# Altium schematic sheet Schematic.SchDoc(Schematic)
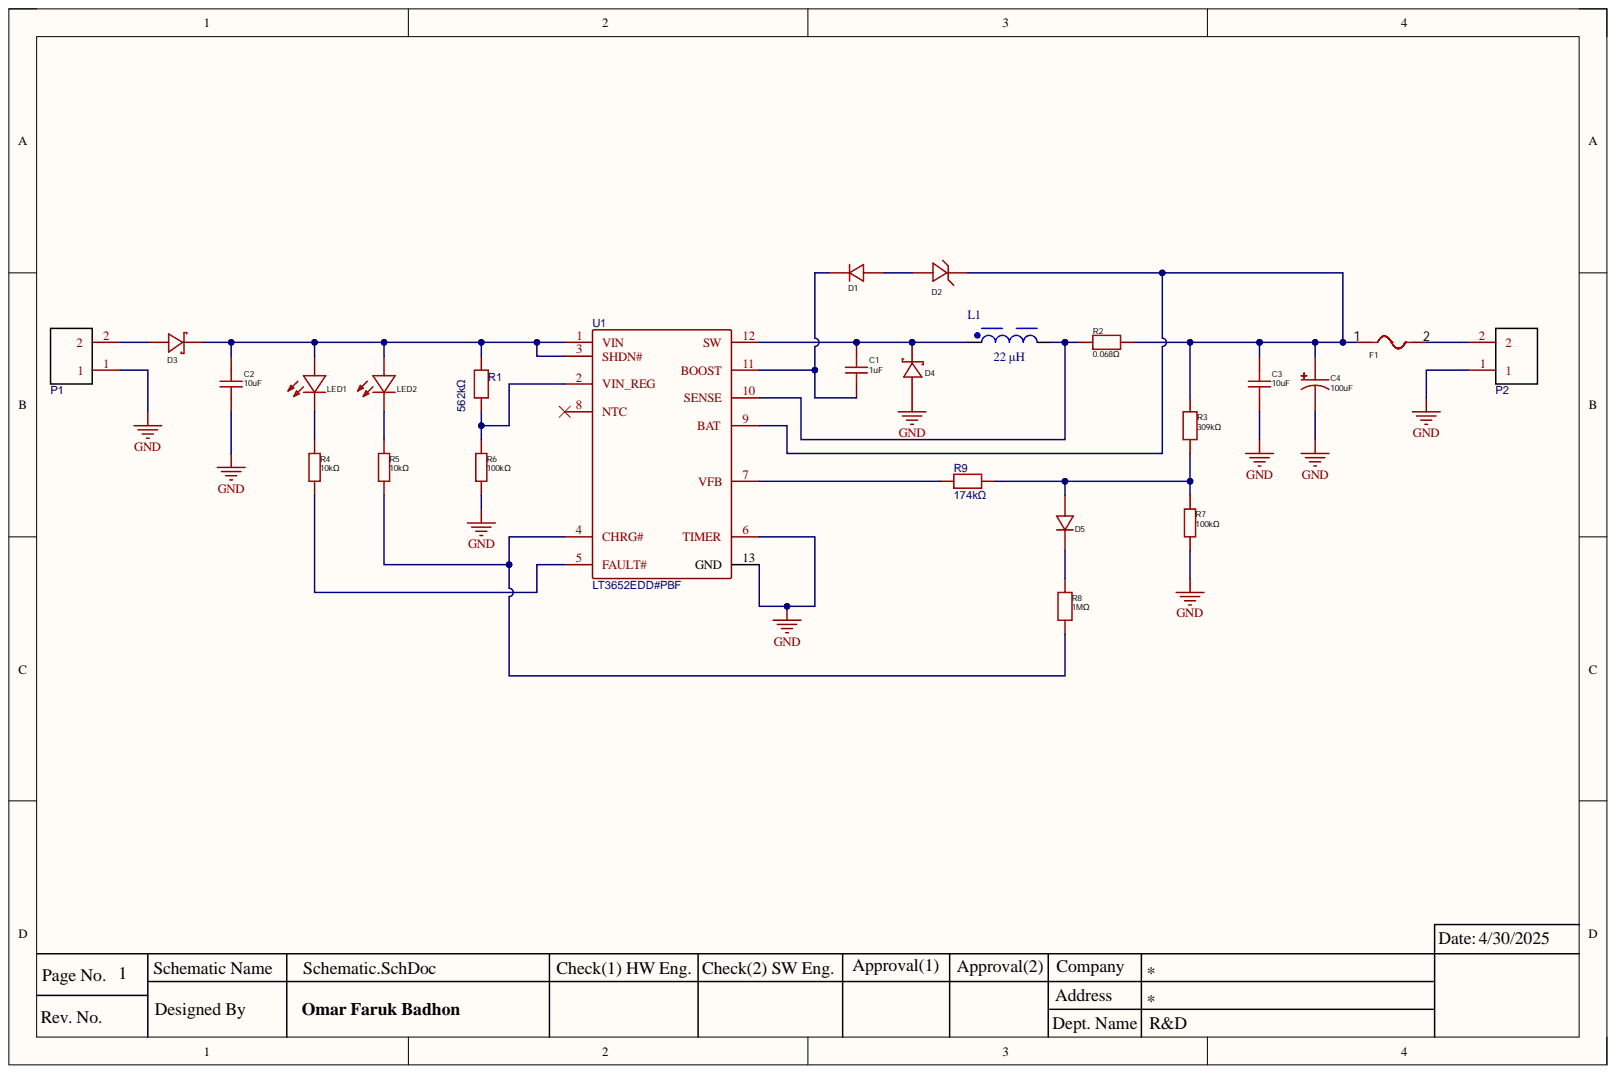
[diagram p0: Schematic.SchDoc]

## Parts
C1  1uF  [C0805]
C2  10uF  [C1206]
C3  10uF  [C1206]
C4  100uF  [CAP-SMD_BD8.0-L8.3-W8.3-LS9.5-FD]
D1  1N4148WS-7-F  [SOD-323_L1.8-W1.3-LS2.5-RD]  (value not drawn)
D2  MMSZ5234BT1G  [SOD-123_L2.8-W1.8-LS3.7-RD]  (value not drawn)
D3  V8PAL45-M3/I  [DO-221BC_L4.3-W2.7-LS5.3-RD]  (value not drawn)
D4  V8PAL45-M3/I  [DO-221BC_L4.3-W2.7-LS5.3-RD]  (value not drawn)
D5  1N4148WS-7-F  [SOD-323_L1.8-W1.3-LS2.5-RD]  (value not drawn)
F1  0437003.WR  [F1206]  (value not drawn)
L1  22 μH  [WE-PD_7345R]
LED1  LTST-C170KRKT  [LED0805-R-RD]  (value not drawn)
LED2  LTST-C170KRKT  [LED0805-R-RD]  (value not drawn)
P1  WJ128V-5.0-2P  [CONN-TH_2P-P5.00_WJ128V-5.0-2P]  (value not drawn)
P2  WJ128V-5.0-2P  [CONN-TH_2P-P5.00_WJ128V-5.0-2P]  (value not drawn)
R1  562kΩ  [R0805]
R2  0.068Ω  [R0805]
R3  309kΩ  [R0805]
R4  10kΩ  [R0805]
R5  10kΩ  [R0805]
R6  100kΩ  [R0805]
R7  100kΩ  [R0805]
R8  1MΩ  [R0805]
R9  174kΩ  [R0805]
U1  LT3652EDD#PBF  [DFN-12_L3.0-W3.0-P0.50-LS3.0-BL-EP]

## Nets
GND: C2.2 C3.1 C4.2 D4.2 P1.1 P2.1 R6.1 R7.1 U1.6(TIMER) U1.13(GND)
NetC1_1: C1.1 D1.1 U1.11(BOOST)
NetC1_2: C1.2 D4.1 L1.1 U1.12(SW)
NetC2_1: C2.1 D3.1 LED1.1 LED2.1 R1.2 U1.1(VIN) U1.3(SHDN#)
NetC3_2: C3.2 C4.1 D2.1 F1.1 R2.2 R3.2 U1.9(BAT)
NetD1_2: D1.2 D2.2
NetD3_2: D3.2 P1.2
NetD5_1: D5.1 R8.2
NetD5_2: D5.2 R3.1 R7.2 R9.2
NetF1_2: F1.2 P2.2
NetL1_2: L1.2 R2.1 U1.10(SENSE)
NetLED1_2: LED1.2 R4.2
NetLED2_2: LED2.2 R5.2
NetR1_1: R1.1 R6.2 U1.2(VIN_REG)
NetR4_1: R4.1 U1.5(FAULT#)
NetR5_1: R5.1 R8.1 U1.4(CHRG#)
NetR9_1: R9.1 U1.7(VFB)

Not connected: U1 8(NTC)

## Library data of the parts
C1 = GRM216R61E105KA12D: 25V 1uF X5R ±10% 0805 Multilayer Ceramic Capacitors MLCC - SMD/SMT ROHS; Manufacturer: muRata(村田); Add into BOM: yes; Component Kind: Standard; Convert to PCB: yes; Datasheet: https://atta.szlcsc.com/upload/public/pdf/source/20191022/C440211_D691AF4420B7318595498C3E4B7F2331.pdf; Device: GRM216R61E105KA12D; JLCPCB Part Class: Extended Part; LCSC Part Name: 1uF ±10% 25V; Library Name: Capacitor.SchLib; Manufacturer Part: GRM216R61E105KA12D; PCB3D: C0805_L2.0-W1.3-H1.3; Supplier: LCSC; Supplier Footprint: 0805; Supplier Part: C440193; Symbol: GRM216R61E105KA12D; Tolerance: ±10%; Value: 1uF
C2 C3 = GRT31CR6YA106KE01L: 35V 10uF X5R ±10% 1206 Multilayer Ceramic Capacitors MLCC - SMD/SMT ROHS; Manufacturer: Murata Electronics; Add into BOM: yes; Component Kind: Standard; Convert to PCB: yes; Device: GRT31CR6YA106KE01L; JLCPCB Part Class: Extended Part; LCSC Part Name: 10uF ±10% 35V; Library Name: Capacitor.SchLib; Manufacturer Part: GRT31CR6YA106KE01L; PCB3D: C1206_L3.2-W1.6-H1.3; Supplier: LCSC; Supplier Footprint: 1206; Supplier Part: C2175512; Symbol: GRT31CR6YA106KE01L; Tolerance: ±10%; Value: 10uF
C4 = EEEHC1E101P: 100uF 25V 130mA@120Hz ±20% SMD,D8xL10.2mm Aluminum Electrolytic Capacitors - SMD ROHS; Manufacturer: PANASONIC(松下); Add into BOM: yes; Lifetime @ Temp: 5000hrs@105℃; Component Kind: Standard; Convert to PCB: yes; Datasheet: https://atta.szlcsc.com/upload/public/pdf/source/20190305/C336336_78C21C8ECF10E68AF1E1A1FAA303815A.pdf; Device: EEEHC1E101P; Equivalent Series Resistance(ESR): -; JLCPCB Part Class: Extended Part; LCSC Part Name: 100uF ±20% 25V; Library Name: Capacitor.SchLib; Manufacturer Part: EEEHC1E101P; Operating Temperature: -40℃~+105℃; PCB3D: CAP-SMD_BD8.0-L8.3-W8.3-LS9.5-FD; Ripple Current: 130mA@120Hz; Supplier: LCSC; Supplier Footprint: SMD,D8xL10.2mm; Supplier Part: C336335; Symbol: EEEHC1E101P; Tolerance: ±20%; Value: 100uF
D1 D5 = 1N4148WS-7-F: 75V Independent Type 1.25V@150mA 4ns 150mA SOD-323 Switching Diodes ROHS; Manufacturer: DIODES(美台); Add into BOM: yes; Reverse Leakage Current: 1uA@75V; Component Kind: Standard; Convert to PCB: yes; Datasheet: https://atta.szlcsc.com/upload/public/pdf/source/20160107/1457707747110.pdf; Device: 1N4148WS-7-F; Diode Configuration: Independent Type; Forward Voltage (Vf@If): 1.25V@150mA; JLCPCB Part Class: Extended Part; LCSC Part Name: 电压:75V 电流:150mA; Library Name: 1N4148WS-7-F.SchLib; Manufacturer Part: 1N4148WS-7-F; Operating Temperature: -65℃~+150℃@(Tj); PCB3D: SOD-323_L1.8-W1.3-LS2.5-RD; Supplier: LCSC; Supplier Footprint: SOD-323; Supplier Part: C60580; Symbol: 1N4148WS-7-F
D2 = MMSZ5234BT1G: Independent Type 5.89V~6.51V 500mW 6.2V SOD-123 Zener Diodes ROHS; Manufacturer: onsemi(安森美); Add into BOM: yes; Impedance(Zzt): 7Ω; Reverse Leakage Current (Ir): 5uA@4V; Component Kind: Standard; Convert to PCB: yes; Datasheet: https://atta.szlcsc.com/upload/public/pdf/source/20221020/24E30AC3B161AD81AE78606E4A7F6AC2.pdf; Device: MMSZ5234BT1G; Diode Configuration: Independent Type; JLCPCB Part Class: Extended Part; LCSC Part Name: MMSZ5234BT1G; Library Name: MMSZ5234BT1G.SchLib; Manufacturer Part: MMSZ5234BT1G; PCB3D: SOD-123_L2.8-W1.8-LS3.7-RD; Pd - Power Dissipation(Pd): 500mW; Supplier: LCSC; Supplier Footprint: SOD-123; Supplier Part: C194181; Symbol: MMSZ5234BT1G; Zener Voltage(Nom): 6.2V; Zener Voltage(Range): 5.89V~6.51V
D3 D4 = V8PAL45-M3/I: 45V 4A 570mV@8A DO-221BC(SMPA) Schottky Diodes ROHS; Manufacturer: VISHAY(威世); Add into BOM: yes; Reverse Leakage Current (Ir): 1.85mA@45V; Component Kind: Standard; Convert to PCB: yes; Datasheet: https://atta.szlcsc.com/upload/public/pdf/source/20231212/E8913B3A928D0C347C4F04EA6F9113F3.pdf; Device: V8PAL45-M3/I; Forward Voltage (Vf@If): 570mV@8A; JLCPCB Part Class: Extended Part; LCSC Part Name: 电压:45V 电流:4A; Library Name: V8PAL45-M3.SchLib; Manufacturer Part: V8PAL45-M3/I; PCB3D: DO-221BC_L4.3-W2.7-LS5.3-H0.9-RD; Rectified Current: 4A; Reverse Voltage (Vr): 45V; Supplier: LCSC; Supplier Footprint: DO-221BC(SMPA); Supplier Part: C3759194; Symbol: V8PAL45-M3/I
F1 = Fuse: 50A SMD Fuse 3A 32V 1206 Disposable fuses ROHS; Manufacturer: Littelfuse(美国力特); Add into BOM: yes; Voltage Rating (DC): 35V; Breaking Capacity: 50A; Component Kind: Standard; Convert to PCB: yes; Current Rating: 3A; Datasheet: https://atta.szlcsc.com/upload/public/pdf/source/20170322/1490151740362.pdf; Device: 0437003.WR; JLCPCB Part Class: Extended Part; LCSC Part Name: 32V贴片式保险丝; Library Name: Fuse.SchLib; Manufacturer Part: 0437003.WR; Operating Temperature: -55℃~+150℃; PCB3D: F1206_L3.2-W1.7-H0.6; Supplier: LCSC; Supplier Footprint: 1206; Supplier Part: C99539; Symbol: 0437003.WR; Type: SMD Fuse; Voltage Rating  (AC): 32V
L1 = 7447779122: WE-PD SMT Power Inductor, size 7345R, 22 μH, 1.41 A, 110 mΩ; Manufacturer: Wurth Elektronik; DC Resistance: 90 mΩ; DC Resistance Max: 110 mΩ; Rated Current: 1.41 A; Saturation Current: 1.7 A; Self Resonant Frequency: 17 MHz; Type: Robust; Case/Size Code: 7345R; Category: Power Inductors; Component Kind: Standard; ComponentLink1Description: Website Link; ComponentLink1URL: https://www.we-online.com/redexpert/article/7447779122?ad; ComponentLink2Description: Datasheet Link; ComponentLink2URL: https://www.we-online.com/redexpert/spec/7447779122?ad; Height: 4.5 mm; Inductance: 22 μH; Length: 7.3 mm; Library Name: WE-PD.SchLib; Manufacturer Part Number: 7447779122; Match Code: WE-PD; Max Operating Temperature: 125 °C; Min Operating Temperature: -40 °C; Mount: Surface Mount; Packaging: Tape and Reel; Simulation: 7345_7447779122_22u; Width: 7.3 mm
LED1 LED2 = LTST-C170KRKT: 20mA 54mcd Colorless Transparent Lens -55℃~+85℃ 631nm Red 130° 75mW 2V 0805 LED Indication - Discrete ROHS; Manufacturer: LITEON(光宝); Add into BOM: yes; Peak Wavelength: 639nm; Color Temperature: -; Component Kind: Standard; Convert to PCB: yes; Datasheet: https://atta.szlcsc.com/upload/public/pdf/source/20170325/1490427902593.pdf; Device: LTST-C170KRKT; Diode Configuration: -; Dominant Wavelength: 631nm; Emitted Color: Red; Forward Current: 20mA; Forward Voltage (VF): 2V; JLCPCB Part Class: Extended Part; LCSC Part Name: LTST-C170KRKT; Lens Color: Colorless Transparent Lens; Library Name: LED.SchLib; Luminous Intensity: 54mcd; Manufacturer Part: LTST-C170KRKT; Mounting Sytle: -; Operating Temperature: -55℃~+85℃; PCB3D: LED0805-RD; Power Dissipation: 75mW; Supplier: LCSC; Supplier Footprint: 0805; Supplier Part: C94868; Symbol: LTST-C170KRKT; Viewing Angle: 130°
P1 P2 = WJ128V-5.0-2P: 1x2P 20A -40℃~+105℃ 250V Green 12~22 Direct Insert 5mm 2.5 1 2 Plugin,P=5mm Screw Terminal Blocks ROHS; Manufacturer: KANGNEX(康奈克斯电气); Component Kind: Standard; JLCPCB Part Class: Extended Part; Library Name: 2P terminal.SchLib; Manufacturer Part: WJ128V-5.0-2P; spicePre: P; spiceSymbolName: WJ128V-5.0-2P; Supplier: LCSC; Supplier Part: C8269
R1 = ERJ6ENF5623V: 0.125W Thick Film Resistors ±100ppm/℃ ±1% 562kΩ 0805 Chip Resistor - Surface Mount ROHS; Manufacturer: PANASONIC(松下); Contributor: LCSC; nameAlias: Value; Component Kind: Standard; JLCPCB Part Class: Extended Part; Library Name: Resistor.SchLib; Manufacturer Part: ERJ6ENF5623V; spicePre: R; spiceSymbolName: ERJ6ENF5623V; Supplier: LCSC; Supplier Part: C3025620
R2 = FRL0805JR068TS: 0.125W Thick Film Resistors 300V ±600ppm/℃ ±5% 0.068Ω 0805 Chip Resistor - Surface Mount ROHS; Manufacturer: FOJAN; Add into BOM: yes; Temperature Coefficient: ±200ppm/℃; Tolerance: ±1%; Value: 0.68Ω; Component Kind: Standard; Convert to PCB: yes; Device: FRL0805JR068TS; JLCPCB Part Class: Extended Part; LCSC Part Name: C3000696; Library Name: Resistor.SchLib; Manufacturer Part: FRL0805JR068TS; Operating Temperature Range: -55℃~+155℃; Overload Voltage (Max): -; PCB3D: R0805_L2.0-W1.3-H0.6; Supplier: LCSC; Supplier Footprint: 0805; Supplier Part: C3000696; Symbol: FRL0805JR068TS; Type: Thick Film Resistors
R3 = ERJ6ENF3093V: 309kΩ 0805 Chip Resistor 0.125W Thick Film Resistors ±100ppm/℃ ±1%  - Surface Mount ROHS; Manufacturer: PANASONIC(松下); Add into BOM: yes; Component Kind: Standard; Convert to PCB: yes; Datasheet: https://atta.szlcsc.com/upload/public/pdf/source/20190806/C410005_411FBB62F8BDC8BC9CE172F2BD7302D6.pdf; Device: ERJ6ENF3093V; JLCPCB Part Class: Extended Part; LCSC Part Name: 309kΩ ±1% 125mW 厚膜电阻; Library Name: Resistor.SchLib; Manufacturer Part: ERJ6ENF3093V; Operating Temperature Range: -55℃~+155℃; Overload Voltage (Max): -; PCB3D: R0805_L2.0-W1.3-H0.6; Supplier: LCSC; Supplier Footprint: 0805; Supplier Part: C410005; Symbol: ERJ6ENF3093V; Temperature Coefficient: ±100ppm/℃; Tolerance: ±1%; Type: Thick Film Resistors; Value: 309kΩ
R4 R5 = ERJPB6B1002V: 10kΩ 0805 Chip Resistor  0.2W Thick Film Resistors 200V ±50ppm/℃ ±0.1% - Surface Mount ROHS; Manufacturer: PANASONIC(松下); Add into BOM: yes; Component Kind: Standard; Convert to PCB: yes; Datasheet: https://atta.szlcsc.com/upload/public/pdf/source/20180416/C191317_151B7FE0B8A326015C369A5828110A93.pdf; Device: ERJPB6B1002V; JLCPCB Part Class: Extended Part; LCSC Part Name: 10kΩ ±0.1% 200mW 厚膜电阻; Library Name: Resistor.SchLib; Manufacturer Part: ERJPB6B1002V; Operating Temperature Range: -55℃~+155℃; PCB3D: R0805_L2.0-W1.3-H0.6; Supplier: LCSC; Supplier Footprint: 0805; Supplier Part: C161788; Symbol: ERJPB6B1002V; Temperature Coefficient: ±50ppm/℃; Tolerance: ±0.1%; Type: Thick Film Resistors; Value: 10kΩ
R6 R7 = ERJ6ENF1003V: 100kΩ 0805 Chip Resistor 0.125W Thick Film Resistors ±100ppm/℃ ±1%  - Surface Mount ROHS; Manufacturer: PANASONIC(松下); Add into BOM: yes; Component Kind: Standard; Convert to PCB: yes; Datasheet: https://atta.szlcsc.com/upload/public/pdf/source/20181222/C169252_7F18A762E4E3D9CA9CBEEE198C53D3F1.pdf; Device: ERJ6ENF1003V; JLCPCB Part Class: Extended Part; LCSC Part Name: 100kΩ ±1% 125mW 厚膜电阻; Library Name: Resistor.SchLib; Manufacturer Part: ERJ6ENF1003V; Operating Temperature Range: -55℃~+155℃; Overload Voltage (Max): -; PCB3D: R0805_L2.0-W1.3-H0.6; Supplier: LCSC; Supplier Footprint: 0805; Supplier Part: C169252; Symbol: ERJ6ENF1003V; Temperature Coefficient: ±100ppm/℃; Tolerance: ±1%; Type: Thick Film Resistors; Value: 100kΩ
R8 = ERJP06J105V: 1MΩ 0805 Chip Resistor  0.5W Thick Film Resistors ±5% ±200ppm/℃ - Surface Mount ROHS; Manufacturer: PANASONIC(松下); Add into BOM: yes; Component Kind: Standard; Convert to PCB: yes; Device: ERJP06J105V; JLCPCB Part Class: Extended Part; LCSC Part Name: 1MΩ ±5% 500mW 厚膜电阻; Library Name: Resistor.SchLib; Manufacturer Part: ERJP06J105V; Operating Temperature Range: -55℃~+155℃; Overload Voltage (Max): -; PCB3D: R0805_L2.0-W1.3-H0.6; Supplier: LCSC; Supplier Footprint: 0805; Supplier Part: C441966; Symbol: ERJP06J105V; Temperature Coefficient: ±200ppm/℃; Tolerance: ±5%; Type: Thick Film Resistors; Value: 1MΩ
R9 = ERJ6ENF1743V: 174kΩ 0805 Chip Resistor  0.125W Thick Film Resistors ±100ppm/℃ ±1% - Surface Mount ROHS; Manufacturer: PANASONIC(松下); Contributor: LCSC; nameAlias: Value; Component Kind: Standard; JLCPCB Part Class: Extended Part; Library Name: Resistor.SchLib; Manufacturer Part: ERJ6ENF1743V; spicePre: R; spiceSymbolName: ERJ6ENF1743V; Supplier: LCSC; Supplier Part: C409978
U1 = LT3652EDD#PBF: 4.95V~32V Multi-Chemical 1~4 2A DFN-12(3x3) Battery Management ROHS; Manufacturer: ADI(亚德诺); Component Kind: Standard; JLCPCB Part Class: Extended Part; Library Name: LT3652EDD#PBF.SchLib; Manufacturer Part: LT3652EDD#PBF; spicePre: U; spiceSymbolName: LT3652EDD#PBF; Supplier: LCSC; Supplier Part: C96510
